# Altium schematic sheet [03] - POWER SUPPLY.SchDoc("[03] - POWER SUPPLY")
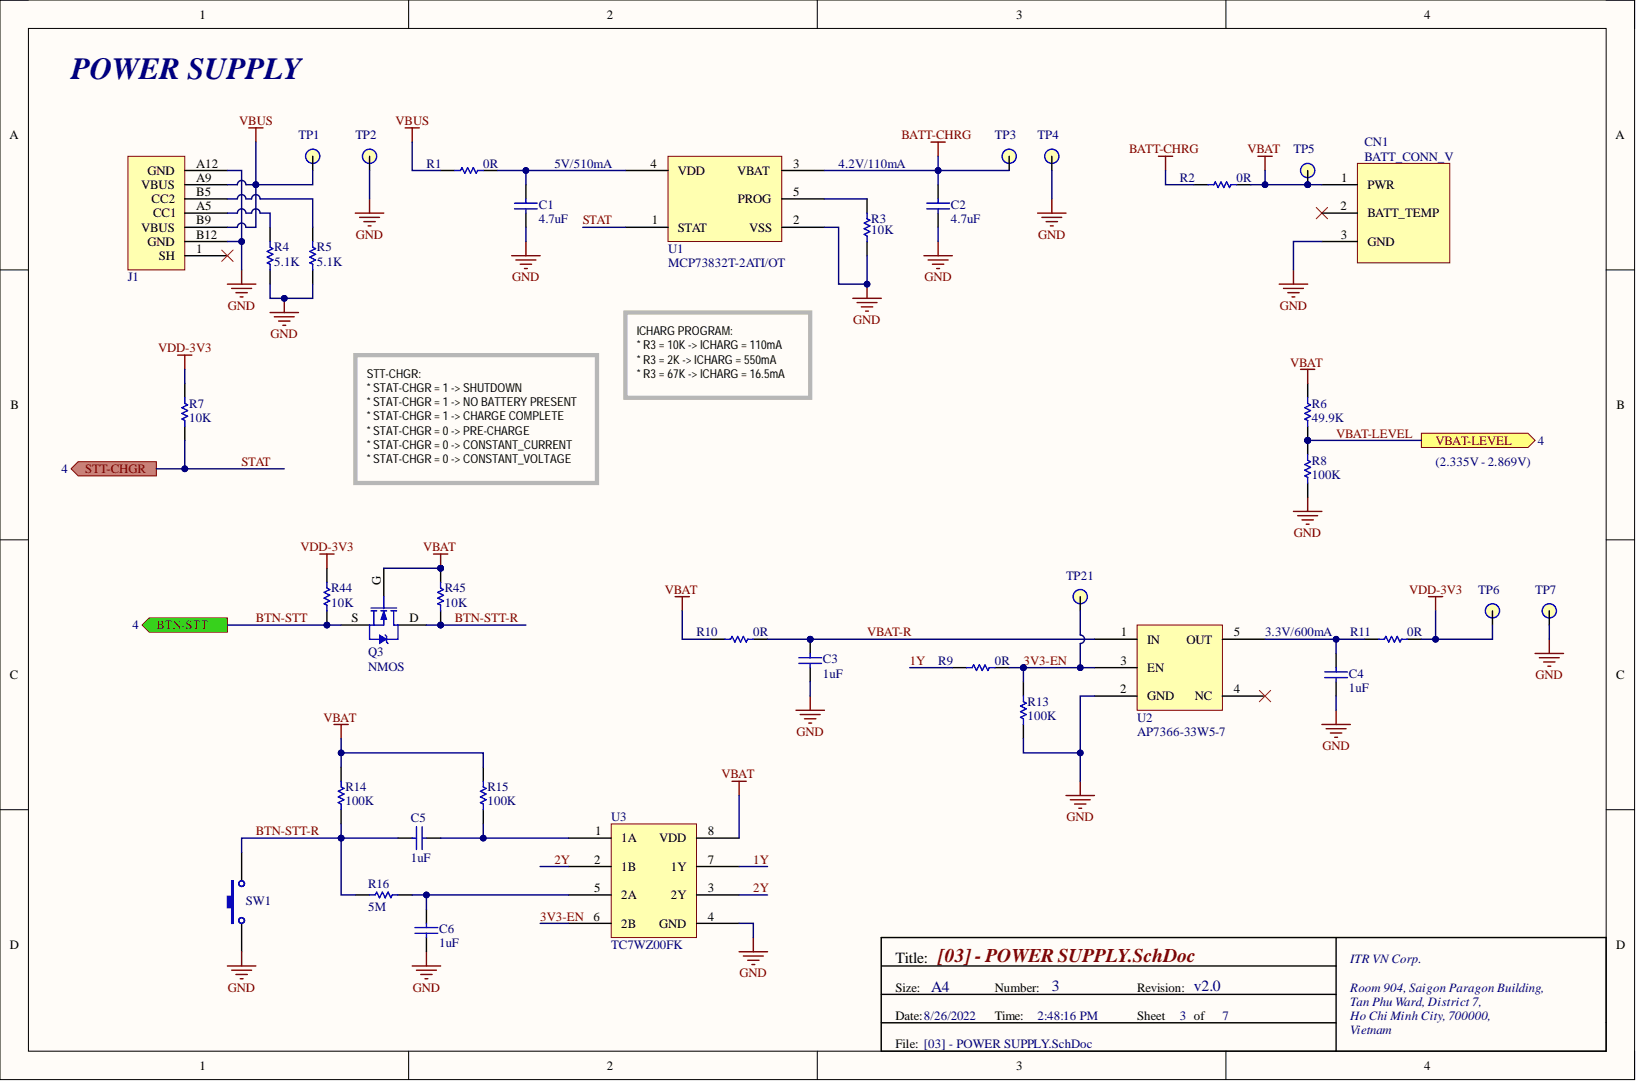
[diagram p0: [03] - POWER SUPPLY.SchDoc]

## Parts
C1  value 4.7uF  Capacitance: 4.7uF  [CAPC1005X55_0402]  (hidden comment: Capacitor)
C2  value 4.7uF  Capacitance: 4.7uF  [CAPC1005X55_0402]  (hidden comment: Capacitor)
C3  value 1uF  Capacitance: 1uF  [CAPC1005X55_0402]  (hidden comment: Capacitor)
C4  value 1uF  Capacitance: 1uF  [CAPC1005X55_0402]  (hidden comment: Capacitor)
C5  value 1uF  Capacitance: 1uF  [CAPC1005X55_0402]  (hidden comment: Capacitor)
C6  value 1uF  Capacitance: 1uF  [CAPC1005X55_0402]  (hidden comment: Capacitor)
CN1  BATT_CONN_V  [0532610371]
J1  USB-C 6P  [USB-C 6P]  (value not drawn)
Q3  NMOS  [SOT190P250X100_3]
R1  value 0R  [RESC1608X55_0603]  (hidden comment: Resistor)
R2  value 0R  [RESC1608X55_0603]  (hidden comment: Resistor)
R3  value 10K  [RESC1005X40_0402]  (hidden comment: Resistor)
R4  value 5.1K  [RESC1005X40_0402]  (hidden comment: Resistor)
R5  value 5.1K  [RESC1005X40_0402]  (hidden comment: Resistor)
R6  value 49.9K  [RESC1005X40_0402]  (hidden comment: Resistor)
R7  value 10K  [RESC1005X40_0402]  (hidden comment: Resistor)
R8  value 100K  [RESC1005X40_0402]  (hidden comment: Resistor)
R9  value 0R  [RESC1005X40_0402]  (hidden comment: Resistor)
R10  value 0R  [RESC1608X55_0603]  (hidden comment: Resistor)
R11  value 0R  [RESC1608X55_0603]  (hidden comment: Resistor)
R13  value 100K  [RESC1005X40_0402]  (hidden comment: Resistor)
R14  value 100K  [RESC1005X40_0402]  (hidden comment: Resistor)
R15  value 100K  [RESC1005X40_0402]  (hidden comment: Resistor)
R16  value 5M  [RESC1005X40_0402]  (hidden comment: Resistor)
R44  value 10K  [RESC1005X40_0402]  (hidden comment: Resistor)
R45  value 10K  [RESC1005X40_0402]  (hidden comment: Resistor)
SW1  Push Button (N.O)  [PTS830]  (value not drawn)
TP1  TP  [TP100]  (no BOM)
TP2  TP  [TP100]  (no BOM)
TP3  TP  [TP100]  (no BOM)
TP4  TP  [TP100]  (no BOM)
TP5  TP  [TP100]  (no BOM)
TP6  TP  [TP100]  (no BOM)
TP7  TP  [TP100]  (no BOM)
TP21  TP  [TP100]  (no BOM)
U1  MCP73832T-2ATI/OT  [MCP73832T-2ATI/OT]
U2  AP7366-33W5-7  [AP7366-33W5-7]
U3  TC7WZ00FK  [TC7WZ00FK]

## Ports
BTN-STT, STT-CHGR, VBAT-LEVEL

## Nets
BATT-CHRG: C2.2 R2.1 TP3.1 U1.3(VBAT)
GND: C1.1 C2.1 C3.1 C4.1 C6.1 CN1.3 J1.A12(GND) J1.B12(GND) R3.1 R4.1 R5.1 R8.1 R13.2 SW1.1 TP2.1 TP4.1 TP7.1 U1.2(VSS) U2.2(GND) U3.4(GND)
VBAT: CN1.1 Q3.G R2.2 R6.1 R10.1 R14.1 R15.1 R45.1 TP5.1 U3.8(VDD)
VBUS: J1.A9(VBUS) J1.B9(VBUS) R1.1 TP1.1
VDD-3V3: R7.1 R11.2 R44.1 TP6.1
1Y: R9.1 U3.7(1Y)
2Y: U3.2(1B) U3.3(2Y)
3V3-EN: R9.2 R13.1 TP21.1 U2.3(EN) U3.6(2B)
BTN-STT: Q3.S R44.2 ; port BTN-STT
BTN-STT-R: C5.2 Q3.D R14.2 R16.1 R45.2 SW1.3
STAT: R7.2 U1.1(STAT) ; port STT-CHGR
VBAT-LEVEL: R6.2 R8.2 ; port VBAT-LEVEL
VBAT-R: C3.2 R10.2 U2.1(IN)
NetC1_2: C1.2 R1.2 U1.4(VDD)
NetC4_2: C4.2 R11.1 U2.5(OUT)
NetC5_1: C5.1 R15.2 U3.1(1A)
NetC6_2: C6.2 R16.2 U3.5(2A)
NetJ1_A5: J1.A5(CC1) R4.2
NetJ1_B5: J1.B5(CC2) R5.2
NetR3_2: R3.2 U1.5(PROG)

Not connected: CN1 2; J1 1(SH); U2 4(NC)

## Library data of the parts
C1 C2 = Capacitor Semi: CAP CER 4.7UF 16V X5R 0402; Manufacturer 1: Samsung; Manufacturer Part Number 1: CL05A475MO5NUNC; Symbol status: Released; Tolerance: 20%; Case Code (Imperial): 0402; Case Code (Metric): 1005; Case/Package: 0402; Category: Ceramic Capacitors; Component Kind: Standard; ComponentLink1Description: http://www.farnell.com/datasheets/2710653.pdf?src-supplier=Farnell; ComponentLink1URL: http://www.farnell.com/datasheets/2710653.pdf?src-supplier=Farnell; ComponentLink2Description: http://www.samsungsem.com/kr/support/product-search/mlcc/CL05A475MO5NUNC.jsp?src-supplier=Digi-Key; ComponentLink2URL: http://www.samsungsem.com/kr/support/product-search/mlcc/CL05A475MO5NUNC.jsp?src-supplier=Digi-Key; ComponentLink3Description: http://www.farnell.com/datasheets/2710653.pdf?src-supplier=Element14; ComponentLink3URL: http://www.farnell.com/datasheets/2710653.pdf?src-supplier=Element14; ComponentLink4Description: http://www.farnell.com/datasheets/2710653.pdf?src-supplier=Newark; ComponentLink4URL: http://www.farnell.com/datasheets/2710653.pdf?src-supplier=Newark; Length: 0.039inch; Library Name: Miscellaneous_Devices.SchLib; Max Operating Temperature: 85°C; Min Operating Temperature: -55°C; Mount: Surface Mount; Packaging: Tape and Reel; Pricing 1: 1=0.46000, 10=0.32100, 50=0.22460, 100=0.19250, 500=0.14438, 1000=0.12191, 2500=0.11550, 5000=0.10908 (USD); RoHS: Yes; RoHS Compliant: Yes; Stock 1: 890644; Supplier 1: Digi-Key; Supplier Part Number 1: 1276-6836-1-ND; Thickness: 0.022inch; Voltage Rating: 16V; Width: 0.02inch
C3-C6 = Capacitor Semi: CAP CER 1UF 16V X5R 0402; Manufacturer 1: Samsung; Manufacturer Part Number 1: CL05A105KO5NNNC; Symbol status: Released; Tolerance: 10%; Case Code (Imperial): 0402; Case Code (Metric): 1005; Case/Package: 0402; Category: Ceramic Capacitors; Component Kind: Standard; ComponentLink1Description: https://datasheet.ciiva.com/16207/cl05a105ko5nnnc-16207571.pdf?src-supplier=Future+Electronics; ComponentLink1URL: https://datasheet.ciiva.com/16207/cl05a105ko5nnnc-16207571.pdf?src-supplier=Future+Electronics; ComponentLink2Description: https://datasheet.ciiva.com/15195/getdatasheetpartid-355272-15195629.pdf?src-supplier=Verical; ComponentLink2URL: https://datasheet.ciiva.com/15195/getdatasheetpartid-355272-15195629.pdf?src-supplier=Verical; Construction: Flat; Contact Plating: Tin; Depth: 0.5mm; Dielectric: X5R; Height: 0.5mm; Length: 1mm; Library Name: Miscellaneous_Devices.SchLib; Max Operating Temperature: 85°C; Min Operating Temperature: -55°C; Mount: Surface Mount; Package Quantity: 1; Packaging: Tape and Reel; Pricing 1: 1=0.10000, 10=0.04200, 50=0.02300, 100=0.01870, 500=0.01338, 1000=0.01051, 2500=0.00956, 5000=0.00879 (USD); Radiation Hardening: No; RoHS: Yes; RoHS Compliant: Yes; Series: CL; Stock 1: 5189685; Supplier 1: Digi-Key; Supplier Part Number 1: 1276-1067-1-ND; Thickness: 0.022inch; Voltage: 16V; Voltage Rating: 16V; Width: 0.02inch
CN1 = BATT_CONN_V: Conn Shrouded Header HDR 3 POS 1.25mm Solder RA SMD PicoBlade™ Embossed T/R; Manufacturer 1: Molex; Manufacturer Part Number 1: 53261-0371; Category: Headers and Wire Housings; Component Kind: Standard; Library Name: SchLib.SchLib; Pricing 1: 1=0.72000, 10=0.61000, 100=0.47430 (USD); RoHS: Yes; Stock 1: 17254; Supplier 1: Digi-Key; Supplier Part Number 1: WM7621CT-ND
J1 = USB-C 6P: USB-C 6P SMD; Component Kind: Standard; Supplier 1: thegioiic.com; Supplier Part Number 1: https://www.thegioiic.com/cong-usb-cai-3-0-type-c-6-chan-thang-dan-smd; Library Name: TENA_V2.0.SchLib
Q3 = NMOS: Trans MOSFET N-CH Si 30V 0.1A 3-Pin S-Mini T/R; Manufacturer 1: Toshiba; Manufacturer Part Number 1: SSM3K15F,LF; Component Kind: Standard; ComponentLink1Description: https://assets.nexperia.com/documents/data-sheet/NX138AK.pdf?src-supplier=Digi-Key; ComponentLink1URL: https://assets.nexperia.com/documents/data-sheet/NX138AK.pdf?src-supplier=Digi-Key; Pricing 1: 1=0.25000, 10=0.20100, 100=0.10660, 500=0.07012, 1000=0.04768 (USD); RoHS: No; Stock 1: 3602; Supplier 1: Digi-Key; Supplier Part Number 1: SSM3K15FLFCT-ND; Library Name: Fiothw_Libs.SchLib
R1 R2 R10 R11 = Res1: RES 0 OHM JUMPER 1/10W 0603; Manufacturer 1: Yageo; Manufacturer Part Number 1: RC0603JR-070RL; BOM Type: A; Power Rating: 100mW; Resistance: 0mR; Temperature Coefficient: 200ppm/°C; Tolerance: 1%; Voltage Rating: 50V; Case Code (Imperial): 0603; Case Code (Metric): 1608; Case/Package: 0603; Category: Chip SMD Resistors; Component Kind: Standard; ComponentLink1Description: https://datasheet.ciiva.com/11808/pyu-r-int-6-11808700.pdf?src-supplier=RSComponents; ComponentLink1URL: https://datasheet.ciiva.com/11808/pyu-r-int-6-11808700.pdf?src-supplier=RSComponents; ComponentLink2Description: https://datasheet.ciiva.com/7746/1738695-7746421.pdf?src-supplier=Element14; ComponentLink2URL: https://datasheet.ciiva.com/7746/1738695-7746421.pdf?src-supplier=Element14; ComponentLink3Description: https://datasheet.ciiva.com/8883/pyu-rc0603-51-rohs-l-4-8883045.pdf?src-supplier=Avnet; ComponentLink3URL: https://datasheet.ciiva.com/8883/pyu-rc0603-51-rohs-l-4-8883045.pdf?src-supplier=Avnet; ComponentLink4Description: https://datasheet.ciiva.com/15189/getdatasheetpartid-329462-15189845.pdf?src-supplier=Verical; ComponentLink4URL: https://datasheet.ciiva.com/15189/getdatasheetpartid-329462-15189845.pdf?src-supplier=Verical; ComponentLink5Description: https://datasheet.ciiva.com/7746/1738695-7746422.pdf?src-supplier=Element14; ComponentLink5URL: https://datasheet.ciiva.com/7746/1738695-7746422.pdf?src-supplier=Element14; ComponentLink6Description: https://datasheet.ciiva.com/12555/rc0603-272842-12555254.pdf?src-supplier=Mouser; ComponentLink6URL: https://datasheet.ciiva.com/12555/rc0603-272842-12555254.pdf?src-supplier=Mouser; ComponentLink7Description: https://datasheet.ciiva.com/22747/443971-22747530.pdf?src-supplier=Element14; ComponentLink7URL: https://datasheet.ciiva.com/22747/443971-22747530.pdf?src-supplier=Element14; Contact Plating: Tin,Matte; Depth: 0.8mm; Height: 0.45mm; Lead Free: Lead Free; Length: 1.6mm; Library Name: Miscellaneous Devices.IntLib; Max Operating Temperature: 155°C; Military Standard: Not; Min Operating Temperature: -55°C; Number of Pins: 2; Package Quantity: 5000; Packaging: Tape and Reel; Pricing 1: 1=0.10000, 10=0.01700, 25=0.01240, 50=0.00960, 100=0.00700, 250=0.00536, 500=0.00428, 1000=0.00315 (USD); Radiation Hardening: No; REACH SVHC: No SVHC; Resistor Type: Thick Film; RoHS: Yes; Signal Integrity: Res; Simulation: RESISTOR; Stock 1: 13836598; Supplier 1: Digi-Key; Supplier Part Number 1: 311-0.0GRCT-ND; Termination: SMD/SMT; Voltage Rating (DC): 50V; Width: 0.8mm
R3 = Resistor: RES SMD 10K OHM 5% 1/10W 0402; Manufacturer 1: Panasonic; Manufacturer Part Number 1: ERJ-2GEJ103X; Max Power Dissipation: 100mW; Resistance: 10kR; Symbol status: Released; Temperature Coefficient: 200ppm/°C; Voltage Rating: 50V; Case Code (Imperial): 0402; Case Code (Metric): 1005; Case/Package: 0402; Category: Chip SMD Resistors; Component Kind: Standard; ComponentLink1Description: https://datasheet.ciiva.com/24070/aoa0000ce1-37422-24070679.pdf?src-supplier=Mouser; ComponentLink1URL: https://datasheet.ciiva.com/24070/aoa0000ce1-37422-24070679.pdf?src-supplier=Mouser; ComponentLink2Description: https://datasheet.ciiva.com/16767/70260739-16767130.pdf?src-supplier=Allied+Electronics; ComponentLink2URL: https://datasheet.ciiva.com/16767/70260739-16767130.pdf?src-supplier=Allied+Electronics; Construction: Rectangular; Contact Plating: Tin; Depth: 0.5mm; Features: Automotive AEC-Q200; Height: 0.35mm; Lead Free: Lead Free; Length: 1mm; Library Name: Miscellaneous_Devices.SchLib; Max Operating Temperature: 155°C; Military Standard: Not; Min Operating Temperature: -55°C; Mount: Surface Mount; Number of Terminations: 2; Package Quantity: 1; Packaging: Cut Tape; Power Rating: 100mW; Radiation Hardening: No; REACH SVHC: No SVHC; Resistance Tolerance: 5%; Resistor Type: Thick Film; RoHS: Yes; RoHS Compliant: Yes; Series: ERJ; Size Code: 0402; Supplier 1: Digi-Key; Supplier Part Number 1: P10KJCT-ND; Technology: THICK FILM; Termination: SMD/SMT; Tolerance: 5%; Voltage Rating (DC): 50V; Width: 0.02inch
R4 R5 = Resistor: RES 5.1K OHM 5% 1/16W 0402; Manufacturer 1: Yageo; Manufacturer Part Number 1: RC0402JR-075K1L; Max Power Dissipation: 63mW; Power Rating: 62.5mW; Resistance: 5.1kR; Symbol status: Released; Temperature Coefficient: 100ppm/°C; Voltage Rating: 50V; Case Code (Imperial): 0402; Case Code (Metric): 1005; Case/Package: 0402; Category: Chip SMD Resistors; Component Kind: Standard; ComponentLink1Description: https://datasheet.ciiva.com/7983/1018594-7983595.pdf?src-supplier=Element14; ComponentLink1URL: https://datasheet.ciiva.com/7983/1018594-7983595.pdf?src-supplier=Element14; ComponentLink2Description: https://datasheet.ciiva.com/16740/pyu-rc0402-51-rohs-l-6-r-16740925.pdf?src-supplier=Future+Electronics; ComponentLink2URL: https://datasheet.ciiva.com/16740/pyu-rc0402-51-rohs-l-6-r-16740925.pdf?src-supplier=Future+Electronics; Construction: Rectangular; Depth: 0.5mm; Features: Moisture Resistant; Height: 0.35mm; Lead Free: Lead Free; Length: 1mm; Library Name: Miscellaneous_Devices.SchLib; Max Operating Temperature: 155°C; Military Standard: Not; Min Operating Temperature: -55°C; Number of Terminations: 2; Packaging: Tape and Reel; Pricing 1: 1=0.10000, 10=0.01300, 100=0.00520, 1000=0.00233, 2500=0.00202, 5000=0.00167 (USD); REACH SVHC: No SVHC; Resistance Tolerance: 5%; Resistor Type: Thick Film; RoHS: Yes; RoHS Compliant: Yes; Series: RC; Size Code: 0402; Stock 1: 1019970; Supplier 1: Digi-Key; Supplier Part Number 1: 311-5.1KJRCT-ND; Termination: SMD/SMT; Tolerance: 5%; Voltage Rating (DC): 50V; Weight: 2.3E-05oz; Width: 0.5mm
R6 = Resistor: RES SMD 49.9K OHM 1% 1/16W 0402; Manufacturer 1: Yageo; Manufacturer Part Number 1: AC0402FR-0749K9L; BOM Type: A; Max Power Dissipation: 63mW; Power Rating: 62.5mW; Resistance: 49.9kR; Symbol status: Released; Temperature Coefficient: 100ppm/°C; Voltage Rating: 50V; Case Code (Imperial): 0402; Case Code (Metric): 1005; Case/Package: 0402; Category: Chip SMD Resistors; Component Kind: Standard; ComponentLink1Description: http://www.mouser.com/ds/2/447/yageodocoutput-1111992.pdf?src-supplier=Mouser; ComponentLink1URL: http://www.mouser.com/ds/2/447/yageodocoutput-1111992.pdf?src-supplier=Mouser; ComponentLink2Description: http://www.yageo.com/NewPortal/yageodocoutput?fileName=/pdf/R-Chip/PYu-AC_51_RoHS_L_6.pdf&src-supplier=Digi-Key; ComponentLink2URL: http://www.yageo.com/NewPortal/yageodocoutput?fileName=/pdf/R-Chip/PYu-AC_51_RoHS_L_6.pdf&src-supplier=Digi-Key; Features: Moisture Resistant,Automotive AEC-Q200; Height: 0.015inch; Length: 0.039inch; Library Name: Miscellaneous_Devices.SchLib; Max Operating Temperature: 155°C; Min Operating Temperature: -55°C; Number of Terminations: 2; Packaging: Tape and Reel; Pricing 1: 1=0.10000, 10=0.01900, 100=0.00780, 1000=0.00352, 2500=0.00306, 5000=0.00253 (USD); Resistor Type: Thick Film; RoHS: Yes; Stock 1: 8999; Supplier 1: Digi-Key; Supplier Part Number 1: YAG3493CT-ND; Termination: SMD/SMT; Tolerance: 1%; Weight: 2.3E-05oz; Width: 0.5mm
R7 = Res1: RES SMD 10K OHM 5% 1/10W 0402; Manufacturer 1: Panasonic; Manufacturer Part Number 1: ERJ-2GEJ103X; BOM Type: A; Max Power Dissipation: 100mW; Resistance: 10kR; Temperature Coefficient: 200ppm/°C; Voltage Rating: 50V; Case Code (Imperial): 0402; Case Code (Metric): 1005; Case/Package: 0402; Category: Chip SMD Resistors; Component Kind: Standard; ComponentLink1Description: https://datasheet.ciiva.com/11821/0900766b80f806dd-11821170.pdf?src-supplier=RSComponents; ComponentLink1URL: https://datasheet.ciiva.com/11821/0900766b80f806dd-11821170.pdf?src-supplier=RSComponents; ComponentLink2Description: https://datasheet.ciiva.com/27055/1935721-27055112.pdf?src-supplier=Jameco; ComponentLink2URL: https://datasheet.ciiva.com/27055/1935721-27055112.pdf?src-supplier=Jameco; ComponentLink3Description: https://datasheet.ciiva.com/17212/aoa0000ce1-17212101.pdf?src-supplier=Avnet+Europe; ComponentLink3URL: https://datasheet.ciiva.com/17212/aoa0000ce1-17212101.pdf?src-supplier=Avnet+Europe; ComponentLink4Description: https://datasheet.ciiva.com/46371/1678796-46371910.pdf?src-supplier=Element14; ComponentLink4URL: https://datasheet.ciiva.com/46371/1678796-46371910.pdf?src-supplier=Element14; ComponentLink5Description: https://datasheet.ciiva.com/24070/aoa0000ce1-37422-24070679.pdf?src-supplier=Mouser; ComponentLink5URL: https://datasheet.ciiva.com/24070/aoa0000ce1-37422-24070679.pdf?src-supplier=Mouser; ComponentLink6Description: https://datasheet.ciiva.com/43460/1678796-43460391.pdf?src-supplier=Farnell; ComponentLink6URL: https://datasheet.ciiva.com/43460/1678796-43460391.pdf?src-supplier=Farnell; ComponentLink7Description: https://datasheet.ciiva.com/16774/70260739-16774504.pdf?src-supplier=Allied+Electronics; ComponentLink7URL: https://datasheet.ciiva.com/16774/70260739-16774504.pdf?src-supplier=Allied+Electronics; Contact Plating: Tin; Depth: 0.5mm; Features: Automotive AEC-Q200; Height: 0.35mm; LatestRevisionDate: 17-Jul-2002; LatestRevisionNote: Re-released for DXP Platform.; Lead Free: Lead Free; Length: 1mm; Library Name: Miscellaneous Devices.IntLib; Max Operating Temperature: 155°C; Military Standard: Not; Min Operating Temperature: -55°C; Mount: Surface Mount; Number of Terminations: 2; Package Quantity: 1; PackageDescription: Axial Device, Thru-Hole; 2 Leads; 0.3 in Pin Spacing; PackageReference: AXIAL-0.3; Packaging: Cut Tape; Power Rating: 100mW; Pricing 1: 1=0.10000, 10=0.01900, 100=0.00770, 1000=0.00345, 2500=0.00299, 5000=0.00247 (USD); Published: 8-Jun-2000; Radiation Hardening: No; REACH SVHC: No SVHC; Resistor Type: Thick Film; RoHS: Yes; Signal Integrity: Res; Simulation: RESISTOR; Stock 1: 2048955; Supplier 1: Digi-Key; Supplier Part Number 1: P10KJCT-ND; Termination: SMD/SMT; Tolerance: 5%; Voltage Rating (DC): 50V; Width: 0.02inch; Publisher: Altium Limited
R8 = Res1: RES SMD 100K OHM 1% 1/10W 0402; Manufacturer 1: Panasonic; Manufacturer Part Number 1: ERJ-2RKF1003X; Max Power Dissipation: 100mW; Resistance: 100kR; Temperature Coefficient: 100ppm/°C; Voltage Rating: 50V; Case Code (Imperial): 0402; Case Code (Metric): 1005; Case/Package: 0402; Category: Chip SMD Resistors; Component Kind: Standard; ComponentLink10Description: https://datasheet.ciiva.com/34303/1987038-34303550.pdf?src-supplier=Jameco; ComponentLink10URL: https://datasheet.ciiva.com/34303/1987038-34303550.pdf?src-supplier=Jameco; ComponentLink11Description: https://datasheet.ciiva.com/11821/0900766b80f80784-11821175.pdf?src-supplier=RSComponents; ComponentLink11URL: https://datasheet.ciiva.com/11821/0900766b80f80784-11821175.pdf?src-supplier=RSComponents; ComponentLink12Description: https://datasheet.ciiva.com/7830/aoa0000ce2-7830725.pdf?src-supplier=Element14; ComponentLink12URL: https://datasheet.ciiva.com/7830/aoa0000ce2-7830725.pdf?src-supplier=Element14; ComponentLink13Description: https://datasheet.ciiva.com/7830/426256-7830974.pdf?src-supplier=Element14; ComponentLink13URL: https://datasheet.ciiva.com/7830/426256-7830974.pdf?src-supplier=Element14; ComponentLink14Description: https://datasheet.ciiva.com/43467/1678782-43467480.pdf?src-supplier=Farnell; ComponentLink14URL: https://datasheet.ciiva.com/43467/1678782-43467480.pdf?src-supplier=Farnell; ComponentLink15Description: https://datasheet.ciiva.com/22723/426256-22723902.pdf?src-supplier=Element14; ComponentLink15URL: https://datasheet.ciiva.com/22723/426256-22723902.pdf?src-supplier=Element14; ComponentLink16Description: https://datasheet.ciiva.com/16778/70260748-16778055.pdf?src-supplier=Allied+Electronics; ComponentLink16URL: https://datasheet.ciiva.com/16778/70260748-16778055.pdf?src-supplier=Allied+Electronics; ComponentLink17Description: https://datasheet.ciiva.com/16784/70260748-16784190.pdf?src-supplier=Allied+Electronics; ComponentLink17URL: https://datasheet.ciiva.com/16784/70260748-16784190.pdf?src-supplier=Allied+Electronics; ComponentLink18Description: https://datasheet.ciiva.com/9186/aoa0000ce2-9186687.pdf?src-supplier=Avnet; ComponentLink18URL: https://datasheet.ciiva.com/9186/aoa0000ce2-9186687.pdf?src-supplier=Avnet; ComponentLink19Description: https://datasheet.ciiva.com/16440/aoa0000ce2-16440217.pdf?src-supplier=Future+Electronics; ComponentLink19URL: https://datasheet.ciiva.com/16440/aoa0000ce2-16440217.pdf?src-supplier=Future+Electronics; ComponentLink1Description: http://www.farnell.com/datasheets/1911175.pdf?src-supplier=Farnell; ComponentLink1URL: http://www.farnell.com/datasheets/1911175.pdf?src-supplier=Farnell; ComponentLink20Description: https://datasheet.ciiva.com/48531/aoa0000ce2-48531070.pdf?src-supplier=Avnet; ComponentLink20URL: https://datasheet.ciiva.com/48531/aoa0000ce2-48531070.pdf?src-supplier=Avnet; ComponentLink21Description: https://datasheet.ciiva.com/30245/aoa0000ce2-30245691.pdf?src-supplier=Onlinecomponents.com; ComponentLink21URL: https://datasheet.ciiva.com/30245/aoa0000ce2-30245691.pdf?src-supplier=Onlinecomponents.com; ComponentLink2Description: https://industrial.panasonic.com/cdbs/www-data/pdf/RDA0000/DMA0000COL11.pdf?src-supplier=Onlinecomponents.com; ComponentLink2URL: https://industrial.panasonic.com/cdbs/www-data/pdf/RDA0000/DMA0000COL11.pdf?src-supplier=Onlinecomponents.com; ComponentLink3Description: http://www.farnell.com/datasheets/1911175.pdf?src-supplier=Element14; ComponentLink3URL: http://www.farnell.com/datasheets/1911175.pdf?src-supplier=Element14; ComponentLink4Description: http://industrial.panasonic.com/cdbs/www-data/pdf/RDA0000/AOA0000C304.pdf?src-supplier=Newark; ComponentLink4URL: http://industrial.panasonic.com/cdbs/www-data/pdf/RDA0000/AOA0000C304.pdf?src-supplier=Newark; ComponentLink5Description: http://www.farnell.com/datasheets/1911175.pdf?src-supplier=Newark; ComponentLink5URL: http://www.farnell.com/datasheets/1911175.pdf?src-supplier=Newark; ComponentLink6Description: http://industrial.panasonic.com/www-data/pdf/AOA0000/AOA0000CE2.pdf?src-supplier=Avnet+Europe; ComponentLink6URL: http://industrial.panasonic.com/www-data/pdf/AOA0000/AOA0000CE2.pdf?src-supplier=Avnet+Europe; ComponentLink7Description: https://industrial.panasonic.com/cdbs/www-data/pdf/RDA0000/DMA0000COL11.pdf?src-supplier=TTI; ComponentLink7URL: https://industrial.panasonic.com/cdbs/www-data/pdf/RDA0000/DMA0000COL11.pdf?src-supplier=TTI; ComponentLink8Description: https://industrial.panasonic.com/cdbs/www-data/pdf/RDA0000/DMA0000COL11.pdf?src-supplier=Freelance+Electronics; ComponentLink8URL: https://industrial.panasonic.com/cdbs/www-data/pdf/RDA0000/DMA0000COL11.pdf?src-supplier=Freelance+Electronics; ComponentLink9Description: https://industrial.panasonic.com/cdbs/www-data/pdf/RDA0000/DMA0000COL11.pdf?src-supplier=NAC+Semi; ComponentLink9URL: https://industrial.panasonic.com/cdbs/www-data/pdf/RDA0000/DMA0000COL11.pdf?src-supplier=NAC+Semi; Contact Plating: Tin; Depth: 0.5mm; Features: Automotive AEC-Q200; Height: 0.35mm; Lead Free: Lead Free; Length: 1mm; Library Name: Miscellaneous Devices.IntLib; Max Operating Temperature: 155°C; Military Standard: Not; Min Operating Temperature: -55°C; Mount: Surface Mount; Number of Pins: 2; Number of Terminations: 2; Package Quantity: 1; Packaging: Cut Tape; Power Rating: 100mW; Pricing 1: 1=0.10000, 10=0.03500, 100=0.01420, 1000=0.00636, 2500=0.00552, 5000=0.00456 (USD); Radiation Hardening: No; REACH SVHC: No SVHC; Resistor Type: Thick Film; RoHS: Yes; RoHS Compliant: Yes; Series: ERJ-2Rx; Signal Integrity: Res; Simulation: RESISTOR; Stock 1: 99827; Supplier 1: Digi-Key; Supplier Part Number 1: P100KLCT-ND; Technology: THICK FILM; Termination: SMD/SMT; Tolerance: 1%; Voltage Rating (DC): 50V; Weight: 0.000388oz; Width: 0.5mm
R9 = Res1: PANASONIC - ERJ2GE0R00X - RES, 0R0, 0.1W, 0402, REEL; Manufacturer Part Number 1: ERJ-2GE0R00X; BOM Type: A; Max Power Dissipation: 100mW; Resistance: 0mR; Temperature Coefficient: 600ppm/°C; Tolerance: 5%; Voltage Rating: 50V; Case Code (Imperial): 0402; Case Code (Metric): 1005; Case/Package: 0402; Category: Chip SMD Resistors; Component Kind: Standard; ComponentLink1Description: https://datasheet.ciiva.com/11821/0900766b80f806dd-11821176.pdf?src-supplier=RSComponents; ComponentLink1URL: https://datasheet.ciiva.com/11821/0900766b80f806dd-11821176.pdf?src-supplier=RSComponents; ComponentLink2Description: https://datasheet.ciiva.com/48531/aoa0000ce1-48531077.pdf?src-supplier=Avnet; ComponentLink2URL: https://datasheet.ciiva.com/48531/aoa0000ce1-48531077.pdf?src-supplier=Avnet; ComponentLink3Description: https://datasheet.ciiva.com/16783/70260739-16783734.pdf?src-supplier=Allied+Electronics; ComponentLink3URL: https://datasheet.ciiva.com/16783/70260739-16783734.pdf?src-supplier=Allied+Electronics; ComponentLink4Description: https://datasheet.ciiva.com/17215/aoa0000ce1-17215386.pdf?src-supplier=Avnet+Europe; ComponentLink4URL: https://datasheet.ciiva.com/17215/aoa0000ce1-17215386.pdf?src-supplier=Avnet+Europe; ComponentLink5Description: https://datasheet.ciiva.com/43460/1678796-43460347.pdf?src-supplier=Farnell; ComponentLink5URL: https://datasheet.ciiva.com/43460/1678796-43460347.pdf?src-supplier=Farnell; ComponentLink6Description: https://datasheet.ciiva.com/5959/1678796-5959692.pdf?src-supplier=Farnell; ComponentLink6URL: https://datasheet.ciiva.com/5959/1678796-5959692.pdf?src-supplier=Farnell; ComponentLink7Description: https://datasheet.ciiva.com/30097/4aoa0000ce1-30097116.pdf?src-supplier=Onlinecomponents.com; ComponentLink7URL: https://datasheet.ciiva.com/30097/4aoa0000ce1-30097116.pdf?src-supplier=Onlinecomponents.com; ComponentLink8Description: https://datasheet.ciiva.com/28695/1678796-28695758.pdf?src-supplier=Element14; ComponentLink8URL: https://datasheet.ciiva.com/28695/1678796-28695758.pdf?src-supplier=Element14; ComponentLink9Description: https://datasheet.ciiva.com/46389/1678796-46389518.pdf?src-supplier=Element14; ComponentLink9URL: https://datasheet.ciiva.com/46389/1678796-46389518.pdf?src-supplier=Element14; Contact Plating: Tin; Depth: 0.5mm; Features: Automotive AEC-Q200; Height: 0.35mm; Lead Free: Lead Free; Length: 1mm; Library Name: Miscellaneous Devices.IntLib; Manufacturer 1: Panasonic; Max Operating Temperature: 155°C; Military Standard: Not; Min Operating Temperature: -55°C; Mount: Surface Mount; Number of Terminations: 2; Package Quantity: 1; Packaging: Tape and Reel; Power Rating: 100mW; Pricing 1: 1=0.10000, 10=0.01900, 25=0.01360, 50=0.01040, 100=0.00770, 250=0.00584, 500=0.00468, 1000=0.00345, 5000=0.00247 (USD); Radiation Hardening: No; REACH SVHC: No SVHC; Resistor Type: Thick Film; RoHS: Yes; Signal Integrity: Res; Simulation: RESISTOR; Stock 1: 15318658; Supplier 1: Digi-Key; Supplier Part Number 1: P0.0JCT-ND; Termination: SMD/SMT; Voltage Rating (DC): 50V; Width: 0.02inch
R13-R15 = Resistor 1: RES SMD 100K OHM 1% 1/10W 0402; Manufacturer 1: Panasonic; Manufacturer Part Number 1: ERJ-2RKF1003X; Max Power Dissipation: 100mW; Power (Watts): 0.1W, 1/10W; Resistance: 100kR; Temperature Coefficient: 100ppm/°C; Voltage Rating: 50V; Case Code (Imperial): 0402; Case Code (Metric): 1005; Case/Package: 0402; Category: Chip SMD Resistors; Component Kind: Standard; ComponentLink10Description: https://datasheet.ciiva.com/9186/aoa0000ce2-9186687.pdf?src-supplier=Avnet; ComponentLink10URL: https://datasheet.ciiva.com/9186/aoa0000ce2-9186687.pdf?src-supplier=Avnet; ComponentLink11Description: https://datasheet.ciiva.com/16440/aoa0000ce2-16440217.pdf?src-supplier=Future+Electronics; ComponentLink11URL: https://datasheet.ciiva.com/16440/aoa0000ce2-16440217.pdf?src-supplier=Future+Electronics; ComponentLink12Description: https://datasheet.ciiva.com/48531/aoa0000ce2-48531070.pdf?src-supplier=Avnet; ComponentLink12URL: https://datasheet.ciiva.com/48531/aoa0000ce2-48531070.pdf?src-supplier=Avnet; ComponentLink13Description: https://datasheet.ciiva.com/30245/aoa0000ce2-30245691.pdf?src-supplier=Onlinecomponents.com; ComponentLink13URL: https://datasheet.ciiva.com/30245/aoa0000ce2-30245691.pdf?src-supplier=Onlinecomponents.com; ComponentLink1Description: https://industrial.panasonic.com/cdbs/www-data/pdf/RDA0000/AOA0000C283.pdf; ComponentLink1URL: https://industrial.panasonic.com/cdbs/www-data/pdf/RDA0000/AOA0000C283.pdf; ComponentLink2Description: https://datasheet.ciiva.com/34303/1987038-34303550.pdf?src-supplier=Jameco; ComponentLink2URL: https://datasheet.ciiva.com/34303/1987038-34303550.pdf?src-supplier=Jameco; ComponentLink3Description: https://datasheet.ciiva.com/11821/0900766b80f80784-11821175.pdf?src-supplier=RSComponents; ComponentLink3URL: https://datasheet.ciiva.com/11821/0900766b80f80784-11821175.pdf?src-supplier=RSComponents; ComponentLink4Description: https://datasheet.ciiva.com/7830/aoa0000ce2-7830725.pdf?src-supplier=Element14; ComponentLink4URL: https://datasheet.ciiva.com/7830/aoa0000ce2-7830725.pdf?src-supplier=Element14; ComponentLink5Description: https://datasheet.ciiva.com/7830/426256-7830974.pdf?src-supplier=Element14; ComponentLink5URL: https://datasheet.ciiva.com/7830/426256-7830974.pdf?src-supplier=Element14; ComponentLink6Description: https://datasheet.ciiva.com/43467/1678782-43467480.pdf?src-supplier=Farnell; ComponentLink6URL: https://datasheet.ciiva.com/43467/1678782-43467480.pdf?src-supplier=Farnell; ComponentLink7Description: https://datasheet.ciiva.com/22723/426256-22723902.pdf?src-supplier=Element14; ComponentLink7URL: https://datasheet.ciiva.com/22723/426256-22723902.pdf?src-supplier=Element14; ComponentLink8Description: https://datasheet.ciiva.com/16778/70260748-16778055.pdf?src-supplier=Allied+Electronics; ComponentLink8URL: https://datasheet.ciiva.com/16778/70260748-16778055.pdf?src-supplier=Allied+Electronics; ComponentLink9Description: https://datasheet.ciiva.com/16784/70260748-16784190.pdf?src-supplier=Allied+Electronics; ComponentLink9URL: https://datasheet.ciiva.com/16784/70260748-16784190.pdf?src-supplier=Allied+Electronics; Composition: Thick Film; Contact Plating: Tin; Depth: 0.5mm; Failure Rate: -; Features: Automotive AEC-Q200; Height: 0.35mm; Height - Seated (Max): 0.016" (0.40mm); Lead Free: Lead Free; Length: 1mm; Library Name: Miscellaneous_Devices.SchLib; Max Operating Temperature: 155°C; Military Standard: Not; Min Operating Temperature: -55°C; Mount: Surface Mount; Number of Pins: 2; Number of Terminations: 2; Operating Temperature: -55°C ~ 155°C; Package Quantity: 10000; Packaging: Cut Tape; Part Status: Active; Power Rating: 100mW; Pricing 1: 1=0.10000, 10=0.03500, 100=0.01420, 1000=0.00636, 2500=0.00552, 5000=0.00456 (USD); Radiation Hardening: No; REACH SVHC: No SVHC; Resistance (Ohms): 100k; Resistor Type: Thick Film; RoHS: Yes; RoHS Compliant: Yes; Series: ERJ-2Rx; Size / Dimension: 0.039" L x 0.020" W (1.00mm x 0.50mm); Stock 1: 99827; Supplier 1: Digi-Key; Supplier Device Package: 0402; Supplier Part Number 1: P100KLCT-ND; Technology: THICK FILM; Termination: SMD/SMT; Tolerance: 1%; Voltage Rating (DC): 50V; Weight: 0.000388oz; Width: 0.5mm
R16 = Resistor: Res Thick Film 0402 5M Ohm 5% 0.05W(1/20W) ±100ppm/C Pad SMD T/R; Manufacturer 1: Ohmite; Manufacturer Part Number 1: HVC0402T5004JET; Max Power Dissipation: 50mW; Resistance: 5MR; Temperature Coefficient: 100ppm/°C; Voltage Rating: 30V; Case Code (Imperial): 0402; Case Code (Metric): 1005; Case/Package: 0402; Category: Chip SMD Resistors; Component Kind: Standard; ComponentLink1Description: https://datasheet.ciiva.com/29699/res-hvc-29699386.pdf?src-supplier=Digi-Key; ComponentLink1URL: https://datasheet.ciiva.com/29699/res-hvc-29699386.pdf?src-supplier=Digi-Key; ComponentLink2Description: https://datasheet.ciiva.com/29721/res-hvc-29721100.pdf?src-supplier=Digi-Key; ComponentLink2URL: https://datasheet.ciiva.com/29721/res-hvc-29721100.pdf?src-supplier=Digi-Key; ComponentLink3Description: https://datasheet.ciiva.com/46422/1806995-46422954.pdf?src-supplier=Element14; ComponentLink3URL: https://datasheet.ciiva.com/46422/1806995-46422954.pdf?src-supplier=Element14; ComponentLink4Description: https://datasheet.ciiva.com/29699/res-hvc-29699387.pdf?src-supplier=Digi-Key; ComponentLink4URL: https://datasheet.ciiva.com/29699/res-hvc-29699387.pdf?src-supplier=Digi-Key; ComponentLink5Description: https://datasheet.ciiva.com/46422/1806995-46422955.pdf?src-supplier=Element14; ComponentLink5URL: https://datasheet.ciiva.com/46422/1806995-46422955.pdf?src-supplier=Element14; ComponentLink6Description: https://datasheet.ciiva.com/46422/1806995-46422956.pdf?src-supplier=Element14; ComponentLink6URL: https://datasheet.ciiva.com/46422/1806995-46422956.pdf?src-supplier=Element14; ComponentLink7Description: https://datasheet.ciiva.com/46422/1806995-46422957.pdf?src-supplier=Element14; ComponentLink7URL: https://datasheet.ciiva.com/46422/1806995-46422957.pdf?src-supplier=Element14; Height: 0.014inch; Length: 0.041inch; Library Name: Miscellaneous_Devices.SchLib; Max Operating Temperature: 155°C; Min Operating Temperature: -55°C; Mount: Surface Mount; Number of Terminations: 2; Package Quantity: 1; Packaging: Cut Tape; Power Rating: 50mW; Pricing 1: 1=2.42000, 10=2.15000, 100=1.64220 (USD); REACH SVHC: Unknown; Resistor Type: Thick Film; RoHS: Yes; RoHS Compliant: Yes; Stock 1: 848; Supplier 1: Digi-Key; Supplier Part Number 1: HVC0402T5004JETCT-ND; Tolerance: 5%; Voltage Rating (DC): 30V; Width: 0.02inch
R44 R45 = Resistor 1: RES SMD 10K OHM 5% 1/10W 0402; Manufacturer 1: Panasonic; Manufacturer Part Number 1: ERJ-2GEJ103X; Max Power Dissipation: 100mW; Resistance: 10kR; Temperature Coefficient: 200ppm/°C; Voltage Rating: 50V; Case Code (Imperial): 0402; Case Code (Metric): 1005; Case/Package: 0402; Category: Chip SMD Resistors; Component Kind: Standard; Construction: Rectangular; Contact Plating: Tin; Depth: 0.5mm; Features: Automotive AEC-Q200; Height: 0.35mm; Lead Free: Lead Free; Length: 1mm; Library Name: Miscellaneous_Devices(1).SchLib; Max Operating Temperature: 155°C; Military Standard: Not; Min Operating Temperature: -55°C; Mount: Surface Mount; Number of Terminations: 2; Package Quantity: 1; Packaging: Cut Tape; Power Rating: 100mW; Radiation Hardening: No; REACH SVHC: No SVHC; Resistance Tolerance: 5%; Resistor Type: Thick Film; RoHS: Yes; RoHS Compliant: Yes; Series: ERJ; Size Code: 0402; Supplier 1: Digi-Key; Supplier Part Number 1: P10KJCT-ND; Technology: THICK FILM; Termination: SMD/SMT; Tolerance: 5%; Voltage Rating (DC): 50V; Width: 0.02inch
  R44: ComponentLink1Description: https://datasheet.ciiva.com/24070/aoa0000ce1-37422-24070679.pdf?src-supplier=Mouser; ComponentLink1URL: https://datasheet.ciiva.com/24070/aoa0000ce1-37422-24070679.pdf?src-supplier=Mouser; ComponentLink2Description: https://datasheet.ciiva.com/16767/70260739-16767130.pdf?src-supplier=Allied+Electronics; ComponentLink2URL: https://datasheet.ciiva.com/16767/70260739-16767130.pdf?src-supplier=Allied+Electronics
  R45: ComponentLink1Description: https://industrial.panasonic.com/cdbs/www-data/pdf/RDA0000/AOA0000C283.pdf; ComponentLink1URL: https://industrial.panasonic.com/cdbs/www-data/pdf/RDA0000/AOA0000C283.pdf; ComponentLink2Description: http://www.yageo.com/NewPortal/yageodocoutput?fileName=/pdf/R-Chip/PYu-RC_51_RoHS_P_0.pdf&src-supplier=Digi-Key; ComponentLink2URL: http://www.yageo.com/NewPortal/yageodocoutput?fileName=/pdf/R-Chip/PYu-RC_51_RoHS_P_0.pdf&src-supplier=Digi-Key; ComponentLink3Description: https://datasheet.ciiva.com/24070/aoa0000ce1-37422-24070679.pdf?src-supplier=Mouser; ComponentLink3URL: https://datasheet.ciiva.com/24070/aoa0000ce1-37422-24070679.pdf?src-supplier=Mouser; ComponentLink4Description: https://datasheet.ciiva.com/16767/70260739-16767130.pdf?src-supplier=Allied+Electronics; ComponentLink4URL: https://datasheet.ciiva.com/16767/70260739-16767130.pdf?src-supplier=Allied+Electronics
SW1 = Push Button (N.O): C&K Series-PTS Tactile Switch PTS830GM140 SMTR LFS; Manufacturer 1: ITT C&K; Actuator Type: Standard; Illumination Type: Non-Illuminated; Mechanical Life: 500000Cycles; Operating Force: 160gf; Switch Function: Off-Mom; Switch Travel: 0.15mm; Symbol status: Released; Throw Configuration: SPST; Category: Tactile Switches; Component Kind: Standard; ComponentLink1Description: https://www.ckswitches.com/media/1478/pts830.pdf?src-supplier=Digi-Key; ComponentLink1URL: https://www.ckswitches.com/media/1478/pts830.pdf?src-supplier=Digi-Key; Library Name: Miscellaneous_Devices.SchLib; Manufacturer Part Number 1: PTS830GM140SMTRLFS; Max Operating Temperature: 85°C; Min Operating Temperature: -40°C; Mount: Surface Mount; Packaging: Tape and Reel; Pricing 1: 1=0.61000, 10=0.59200, 25=0.57040, 100=0.49350, 250=0.44968, 500=0.41676, 1000=0.37290, 2500=0.35315 (USD); RoHS: Yes; RoHS Compliant: Yes; Stock 1: 196017; Supplier 1: Digi-Key; Supplier Part Number 1: CKN10587CT-ND; Termination: Gull Wing
TP1-TP7 TP21 = TEST_POINT: Library Name: Miscellaneous_Devices.SchLib
U1 = MCP73832T-2ATI/OT: Microchip MCP73832T-2ATI/OT; Battery Charge Controller; 500mA; 5-Pin SOT-23; Max Input Voltage: 7V; Max Output Voltage: 4.232V; Max Supply Voltage: 6V; Min Output Voltage: 4.168V; Min Supply Voltage: 3.75V; Number of Cells: 1; Operating Supply Current: 510uA; Output Current: 10mA; Output Voltage: 4.2V; Case/Package: SOT-23; Category: Battery Management; Component Kind: Standard; ComponentLink1Description: https://datasheet.ciiva.com/1137/630369-1137827.pdf?src-supplier=Element14; ComponentLink1URL: https://datasheet.ciiva.com/1137/630369-1137827.pdf?src-supplier=Element14; ComponentLink2Description: https://datasheet.ciiva.com/15115/getdatasheetpartid-574102-15115383.pdf?src-supplier=Verical; ComponentLink2URL: https://datasheet.ciiva.com/15115/getdatasheetpartid-574102-15115383.pdf?src-supplier=Verical; ComponentLink3Description: https://datasheet.ciiva.com/1932/21984e-1932956.pdf?src-supplier=Digi-Key; ComponentLink3URL: https://datasheet.ciiva.com/1932/21984e-1932956.pdf?src-supplier=Digi-Key; ComponentLink4Description: https://datasheet.ciiva.com/1932/21984e-1932957.pdf?src-supplier=Digi-Key; ComponentLink4URL: https://datasheet.ciiva.com/1932/21984e-1932957.pdf?src-supplier=Digi-Key; ComponentLink5Description: https://datasheet.ciiva.com/1932/21984e-1932955.pdf?src-supplier=Digi-Key; ComponentLink5URL: https://datasheet.ciiva.com/1932/21984e-1932955.pdf?src-supplier=Digi-Key; Height: 1.3mm; Lead Free: Lead Free; Length: 3.1mm; Manufacturer 1: Microchip; Manufacturer Part Number 1: MCP73832T-2ATI/OT; Max Charge Current: 500mA; Max Operating Temperature: 85°C; Max Output Current: 500mA; Min Operating Temperature: -40°C; Mount: Surface Mount; Number of Channels: 1; Number of Pins: 5; Package Quantity: 3000; Packaging: Tape and Reel; Pricing 1: 1=0.76000, 25=0.69000 (USD); Radiation Hardening: No; REACH SVHC: No SVHC; RoHS: Yes; RoHS Compliant: Yes; Series: MCP73832; Stock 1: 5846; Supplier 1: Digi-Key; Supplier Part Number 1: MCP73832T-2ATI/OTCT-ND; Width: 1.8mm; Library Name: TENA_V2.0.SchLib
U2 = AP7366-33W5-7: Ldo, Fixed, 3.3V, 0.6A, -40 To 85Deg C Rohs Compliant: Yes; Manufacturer 1: Diodes; Component Kind: Standard; Manufacturer Part Number 1: AP7366-33W5-7; Pricing 1: 1=0.51000, 10=0.37800, 25=0.33080, 100=0.21420, 250=0.17728, 500=0.14182, 1000=0.10873 (USD); RoHS: Yes; Stock 1: 8140; Supplier 1: Digi-Key; Supplier Part Number 1: AP7366-33W5-7DICT-ND; Library Name: TENA_V2.0.SchLib
U3 = TC7WZ00FK: NAND Gate 2-Element 2-IN CMOS Automotive 8-Pin SSOP T/R; Manufacturer 1: Toshiba; Manufacturer Part Number 1: TC7WZ00FK,LJ(CT; Category: Logic Gates; Component Kind: Standard; ComponentLink1Description: https://toshiba.semicon-storage.com/info/docget.jsp?did=54670&prodName=TC7WZ00FK&src-supplier=Digi-Key; ComponentLink1URL: https://toshiba.semicon-storage.com/info/docget.jsp?did=54670&prodName=TC7WZ00FK&src-supplier=Digi-Key; Pricing 1: 1=0.40000, 10=0.30400, 25=0.27400, 100=0.18930, 250=0.15944, 500=0.12954, 1000=0.09964 (USD); RoHS: No; RoHS Compliant: No; Stock 1: 5705; Supplier 1: Digi-Key; Supplier Part Number 1: TC7WZ00FKLJ(CTCT-ND; Library Name: TENA_V2.0.SchLib
